# Altium schematic sheet 02.RESET.SchDoc
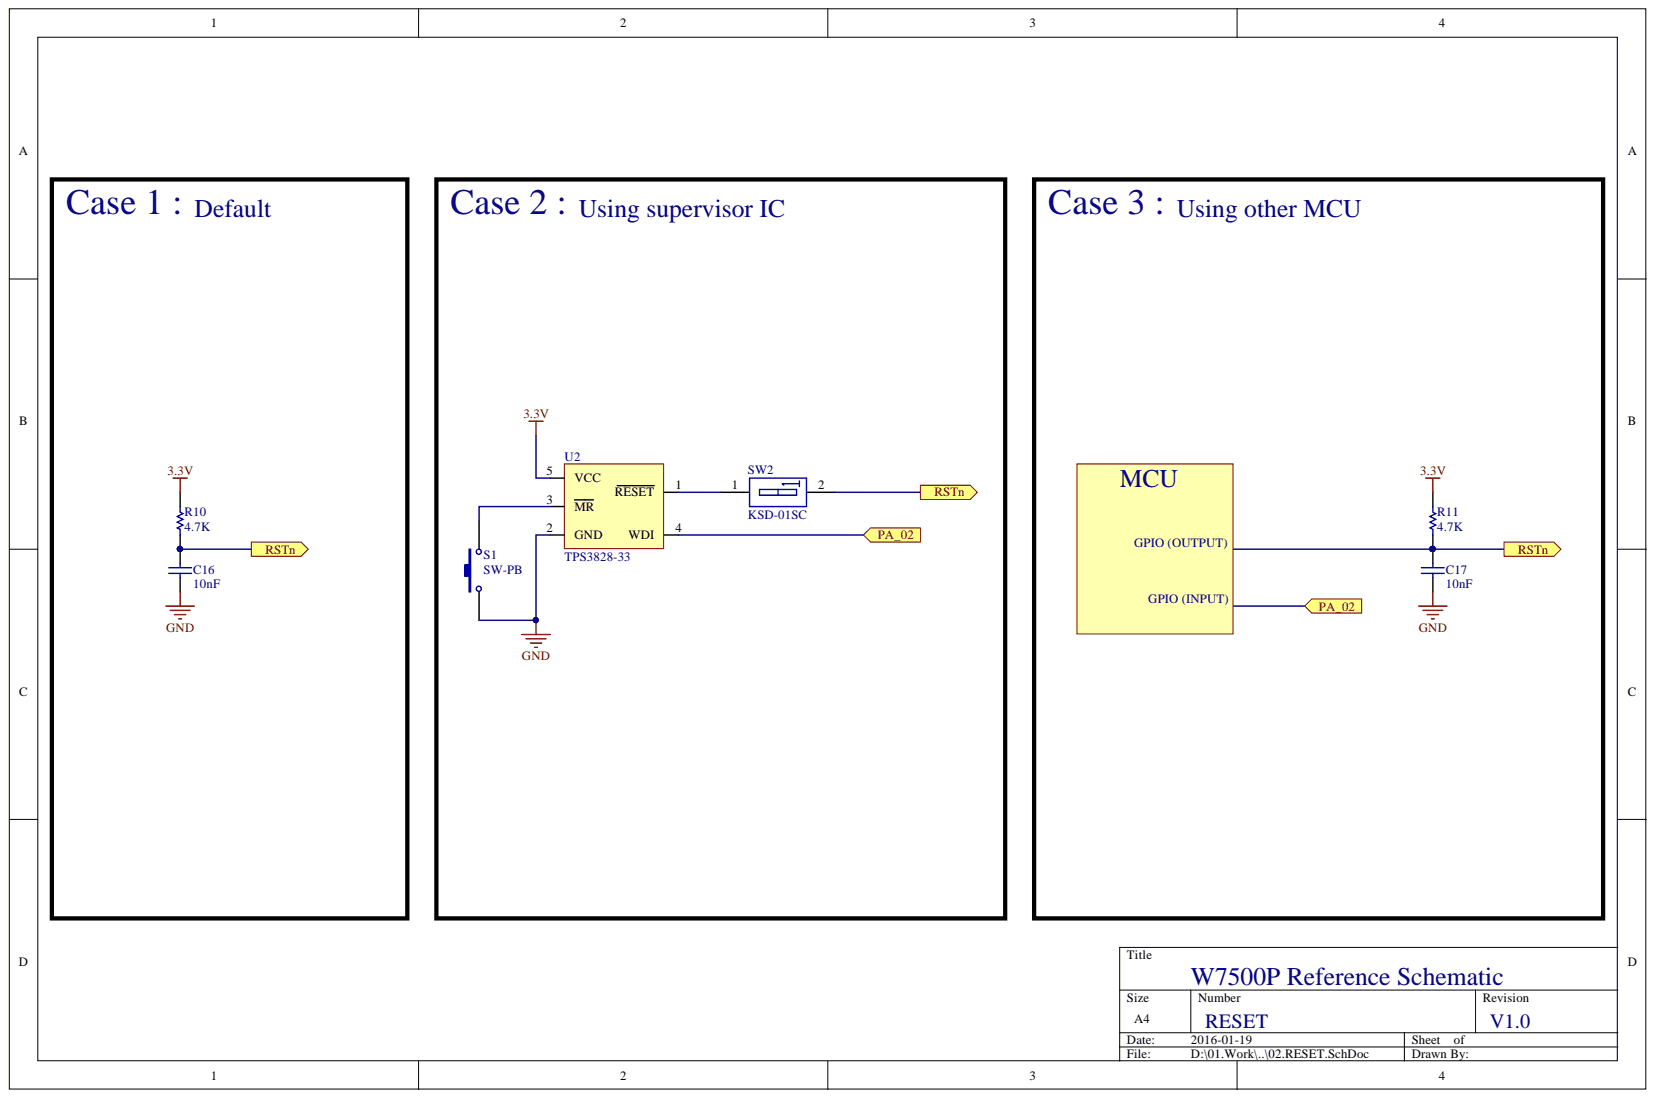
[diagram p0: 02.RESET.SchDoc]

## Parts
C16  10nF  [CAP-0603]
C17  10nF  [CAP-0603]
R10  4.7K  [RES-0603]
R11  4.7K  [RES-0603]
S1  SW-PB  [SPST-2]
SW2  KSD-01SC
U2  TPS3828-33

## Ports
PA_02, RSTN

## Nets
3.3V: R10.2 R11.2 U2.5(VCC)
GND: C16.1 C17.1 S1.1 U2.2(GND)
PA_02: U2.4(WDI) ; port PA_02
NetC16_2: C16.2 C17.2 R10.1 R11.1 SW2.2 ; port RSTN
NetS1_2: S1.2 U2.3(MR)
NetSW2_1: SW2.1 U2.1(RESET)

## Library data of the parts
C16 C17 = CAP-0603: Ceramic CAP 0603(1608); Component Kind: Standard; Library Name: Scott_lib.IntLib
R10 R11 = RES-0603: Component Kind: Standard; Description: RES-0603; Library Name: Scott_lib.IntLib
S1 = SW-PB: Switch; Component Kind: Standard; LatestRevisionDate: 17-Jul-2002; LatestRevisionNote: Re-released for DXP Platform.; Library Name: Miscellaneous Devices.IntLib; PackageDescription: Switch; 2 Leads; PackageReference: SPST-2; Published: 24-Mar-1999; Publisher: Altium Limited
SW2 = KSD-01SC: DIP Switch, 1 Position, SPST; Component Kind: Standard; LatestRevisionDate: 17-Jul-2002; LatestRevisionNote: Re-released for DXP Platform.; PackageReference: DIP-4; Published: 24-Mar-1999; Library Name: Scott_lib.IntLib; Publisher: Altium Limited
U2 = TPS3828-33: Processor Supervisory Circuits; Component Kind: Standard; Library Name: Scott_lib.IntLib
